# Altium schematic sheet LCD_MINIPCIE.SchDoc(LCD_MINIPCIE)
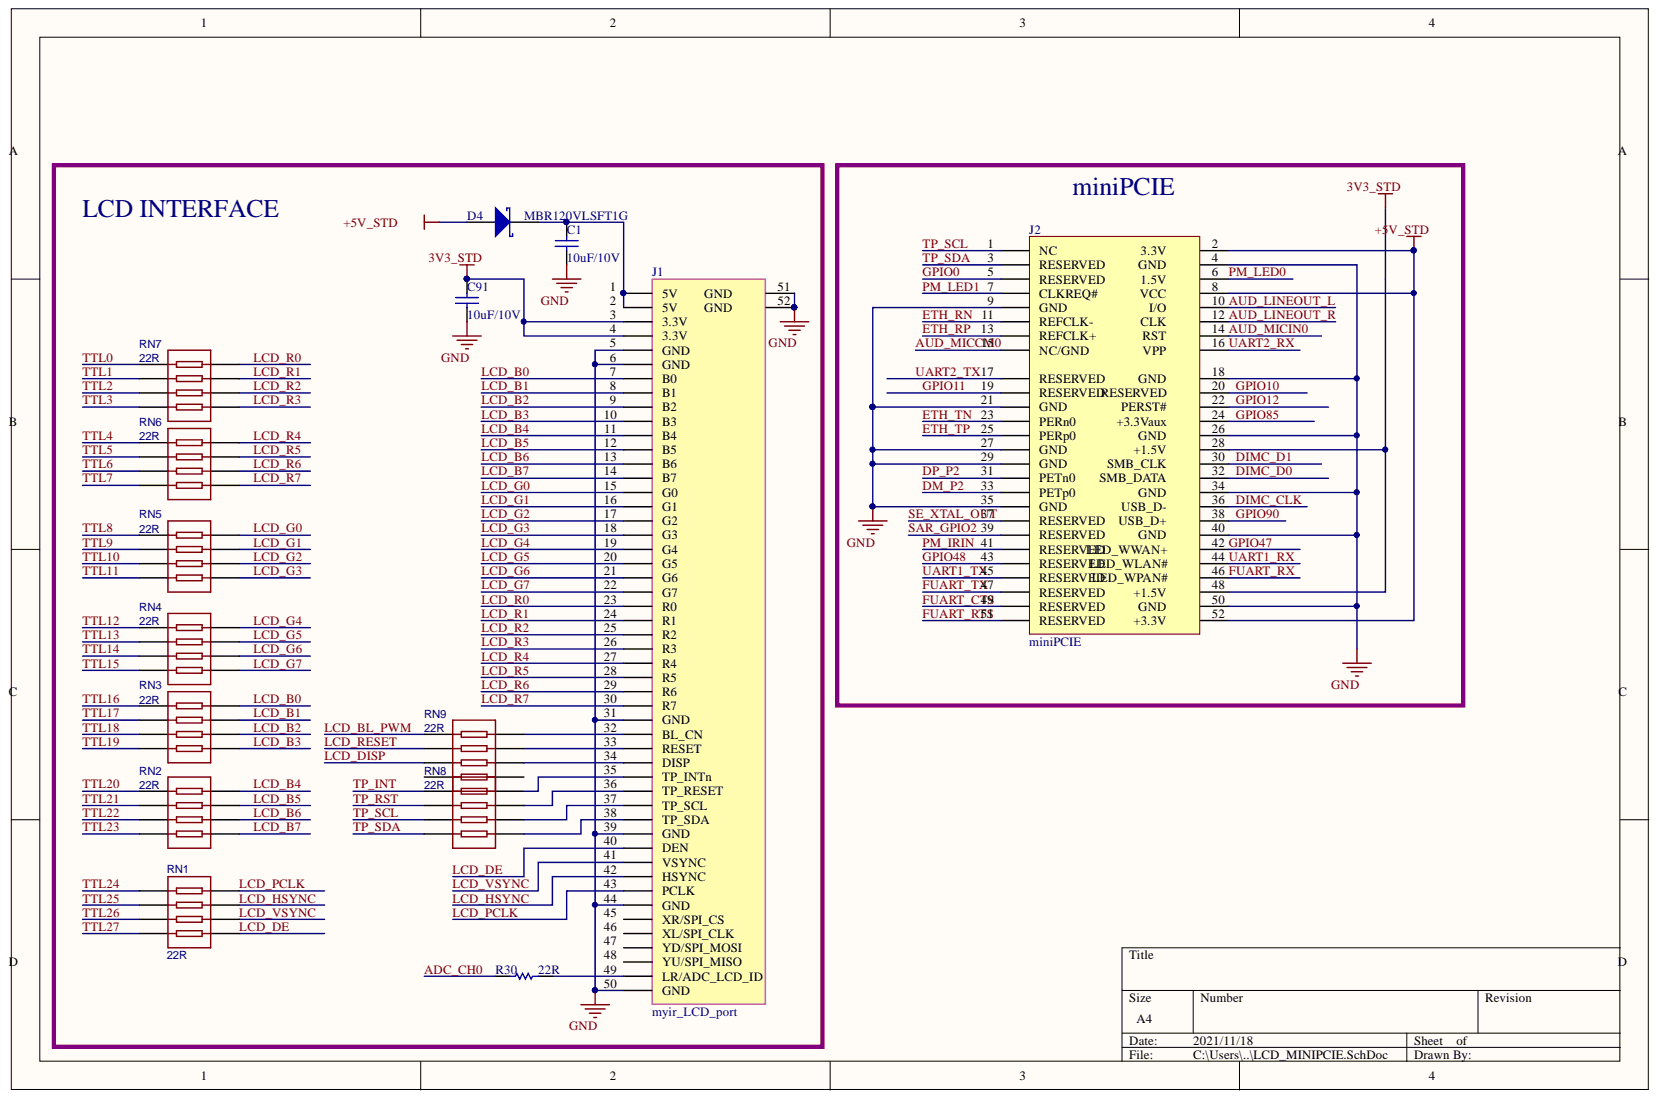
[diagram p0: LCD_MINIPCIE.SchDoc]

## Parts
C1  10uF/10V  [0603]
C91  10uF/10V  [0603]
D4  MBR120VLSFT1G  [SOD-123_L2.8-W1.8-LS3.7-RD]
J1  myir_LCD_port  [FPC50_0A5_SM_H]
J2  miniPCIE  [miniPCIe]
R30  22R  [0402]
RN1  22R  [RES-ARRAY-SMD_0402-8P-L2.0-W1.0-BL]
RN2  22R  [RES-ARRAY-SMD_0402-8P-L2.0-W1.0-BL]
RN3  22R  [RES-ARRAY-SMD_0402-8P-L2.0-W1.0-BL]
RN4  22R  [RES-ARRAY-SMD_0402-8P-L2.0-W1.0-BL]
RN5  22R  [RES-ARRAY-SMD_0402-8P-L2.0-W1.0-BL]
RN6  22R  [RES-ARRAY-SMD_0402-8P-L2.0-W1.0-BL]
RN7  22R  [RES-ARRAY-SMD_0402-8P-L2.0-W1.0-BL]
RN8  22R  [RES-ARRAY-SMD_0402-8P-L2.0-W1.0-BL]
RN9  22R  [RES-ARRAY-SMD_0402-8P-L2.0-W1.0-BL]

## Nets
3V3_STD: C91.2 J1.3(3.3V) J1.4(3.3V) J2.28 J2.48
+5V_STD: D4.1 J2.2 J2.8 J2.52
GND: C1.1 C91.1 J1.5(GND) J1.6(GND) J1.31(GND) J1.39(GND) J1.44(GND) J1.50(GND) J1.51 J1.52 J2.4 J2.9(GND) J2.18 J2.21(GND) J2.26 J2.27(GND) J2.29(GND) J2.34 J2.35(GND) J2.40 J2.50
ADC_CH0: R30.2
AUD_LINEOUT_L: J2.10
AUD_LINEOUT_R: J2.12
AUD_MICCM0: J2.15(NC/GND)
AUD_MICIN0: J2.14
DIMC_CLK: J2.36
DIMC_D0: J2.32
DIMC_D1: J2.30
DM_P2: J2.33(PETp0)
DP_P2: J2.31(PETn0)
ETH_RN: J2.11(REFCLK-)
ETH_RP: J2.13(REFCLK+)
ETH_TN: J2.23(PERn0)
ETH_TP: J2.25(PERp0)
FUART_CTS: J2.49(RESERVED)
FUART_RTS: J2.51(RESERVED)
FUART_RX: J2.46
FUART_TX: J2.47(RESERVED)
GPIO0: J2.5(RESERVED)
GPIO10: J2.20
GPIO11: J2.19(RESERVED)
GPIO12: J2.22
GPIO47: J2.42
GPIO48: J2.43(RESERVED)
GPIO85: J2.24
GPIO90: J2.38
LCD_B0: J1.7(B0) RN3.8
LCD_B1: J1.8(B1) RN3.7
LCD_B2: J1.9(B2) RN3.6
LCD_B3: J1.10(B3) RN3.5
LCD_B4: J1.11(B4) RN2.8
LCD_B5: J1.12(B5) RN2.7
LCD_B6: J1.13(B6) RN2.6
LCD_B7: J1.14(B7) RN2.5
LCD_BL_PWM: RN9.1
LCD_DE: J1.40(DEN) RN1.4
LCD_DISP: RN9.3
LCD_G0: J1.15(G0) RN5.8
LCD_G1: J1.16(G1) RN5.7
LCD_G2: J1.17(G2) RN5.6
LCD_G3: J1.18(G3) RN5.5
LCD_G4: J1.19(G4) RN4.8
LCD_G5: J1.20(G5) RN4.7
LCD_G6: J1.21(G6) RN4.6
LCD_G7: J1.22(G7) RN4.5
LCD_HSYNC: J1.42(HSYNC) RN1.2
LCD_PCLK: J1.43(PCLK) RN1.1
LCD_R0: J1.23(R0) RN7.8
LCD_R1: J1.24(R1) RN7.7
LCD_R2: J1.25(R2) RN7.6
LCD_R3: J1.26(R3) RN7.5
LCD_R4: J1.27(R4) RN6.8
LCD_R5: J1.28(R5) RN6.7
LCD_R6: J1.29(R6) RN6.6
LCD_R7: J1.30(R7) RN6.5
LCD_RESET: RN9.2
LCD_VSYNC: J1.41(VSYNC) RN1.3
PM_IRIN: J2.41(RESERVED)
PM_LED0: J2.6
PM_LED1: J2.7(CLKREQ#)
SAR_GPIO2: J2.39(RESERVED)
SE_XTAL_OUT: J2.37(RESERVED)
TP_INT: RN8.1
TP_RST: RN8.2
TP_SCL: J2.1(NC) RN8.3
TP_SDA: J2.3(RESERVED) RN8.4
TTL0: RN7.1
TTL1: RN7.2
TTL2: RN7.3
TTL3: RN7.4
TTL4: RN6.1
TTL5: RN6.2
TTL6: RN6.3
TTL7: RN6.4
TTL8: RN5.1
TTL9: RN5.2
TTL10: RN5.3
TTL11: RN5.4
TTL12: RN4.1
TTL13: RN4.2
TTL14: RN4.3
TTL15: RN4.4
TTL16: RN3.1
TTL17: RN3.2
TTL18: RN3.3
TTL19: RN3.4
TTL20: RN2.1
TTL21: RN2.2
TTL22: RN2.3
TTL23: RN2.4
TTL24: RN1.8
TTL25: RN1.7
TTL26: RN1.6
TTL27: RN1.5
UART1_RX: J2.44
UART1_TX: J2.45(RESERVED)
UART2_RX: J2.16
UART2_TX: J2.17(RESERVED)
NetC1_2: C1.2 D4.2 J1.1(5V) J1.2(5V)
NetJ1_32: J1.32(BL_CN) RN9.8
NetJ1_33: J1.33(RESET) RN9.7
NetJ1_34: J1.34(DISP) RN9.6
NetJ1_35: J1.35(TP_INTn) RN8.8
NetJ1_36: J1.36(TP_RESET) RN8.7
NetJ1_37: J1.37(TP_SCL) RN8.6
NetJ1_38: J1.38(TP_SDA) RN8.5
NetJ1_49: J1.49(LR/ADC_LCD_ID) R30.1

Not connected: J1 45(XR/SPI_CS) 46(XL/SPI_CLK) 47(YD/SPI_MOSI) 48(YU/SPI_MISO); RN9 4 5

## Library data of the parts
C1 C91 = Cap: Capacitor; PartNumber: CAP100NF; Component Kind: Standard; LatestRevisionDate: 17-Jul-2002; LatestRevisionNote: Re-released for DXP Platform.; Library Name: Miscellaneous Devices.IntLib; PackageReference: 0402; Published: 8-Jun-2000; Signal Integrity: Cap; Simulation: CAP; Value: 10uF/10V; Publisher: Altium Limited
D4 = D Schottky: Schottky Diode; Code_JEDEC: DO-214-AA; Component Kind: Standard; LatestRevisionDate: 17-Jul-2002; LatestRevisionNote: Re-released for DXP Platform.; Library Name: Miscellaneous Devices.IntLib; PackageDescription: DO-214-AA/SMB; 2 C-Bend Leads; Body 5.3 x 3.6 mm, inc. leads (LxW); PackageReference: SMB; Published: 8-Jun-2000; Signal Integrity: D Schottky; Simulation: SKYDIODE; Value: MBR120VLSFT1G; Publisher: Altium Limited
R30 = Res1: Resistor; PartNumber: RES2.2K; Component Kind: Standard; LatestRevisionDate: 17-Jul-2002; LatestRevisionNote: Re-released for DXP Platform.; Library Name: Miscellaneous Devices.IntLib; PackageReference: 0402; Published: 8-Jun-2000; Signal Integrity: Res; Simulation: RESISTOR; Value: 22R; Publisher: Altium Limited
RN1-RN9 = RES_ARRAY: BOM_Manufacturer: RALEC; BOM_Manufacturer Part: RTA02-4D220JTH; nameAlias: Resistance (Ohms); BOM_JLCPCB Part Class: Extended Part; BOM_Supplier: LCSC; BOM_Supplier Part: C102632; Component Kind: Standard; Library Name: HM2.SchLib; spicePre: R; spiceSymbolName: RTA02-4D220JTH
J1 = myir_LCD_port: Component Kind: Standard; Library Name: HM2.SchLib
J2 = miniPCIE: Component Kind: Standard; Library Name: HM2.SchLib
